# Altium schematic sheet Modul.SchDoc
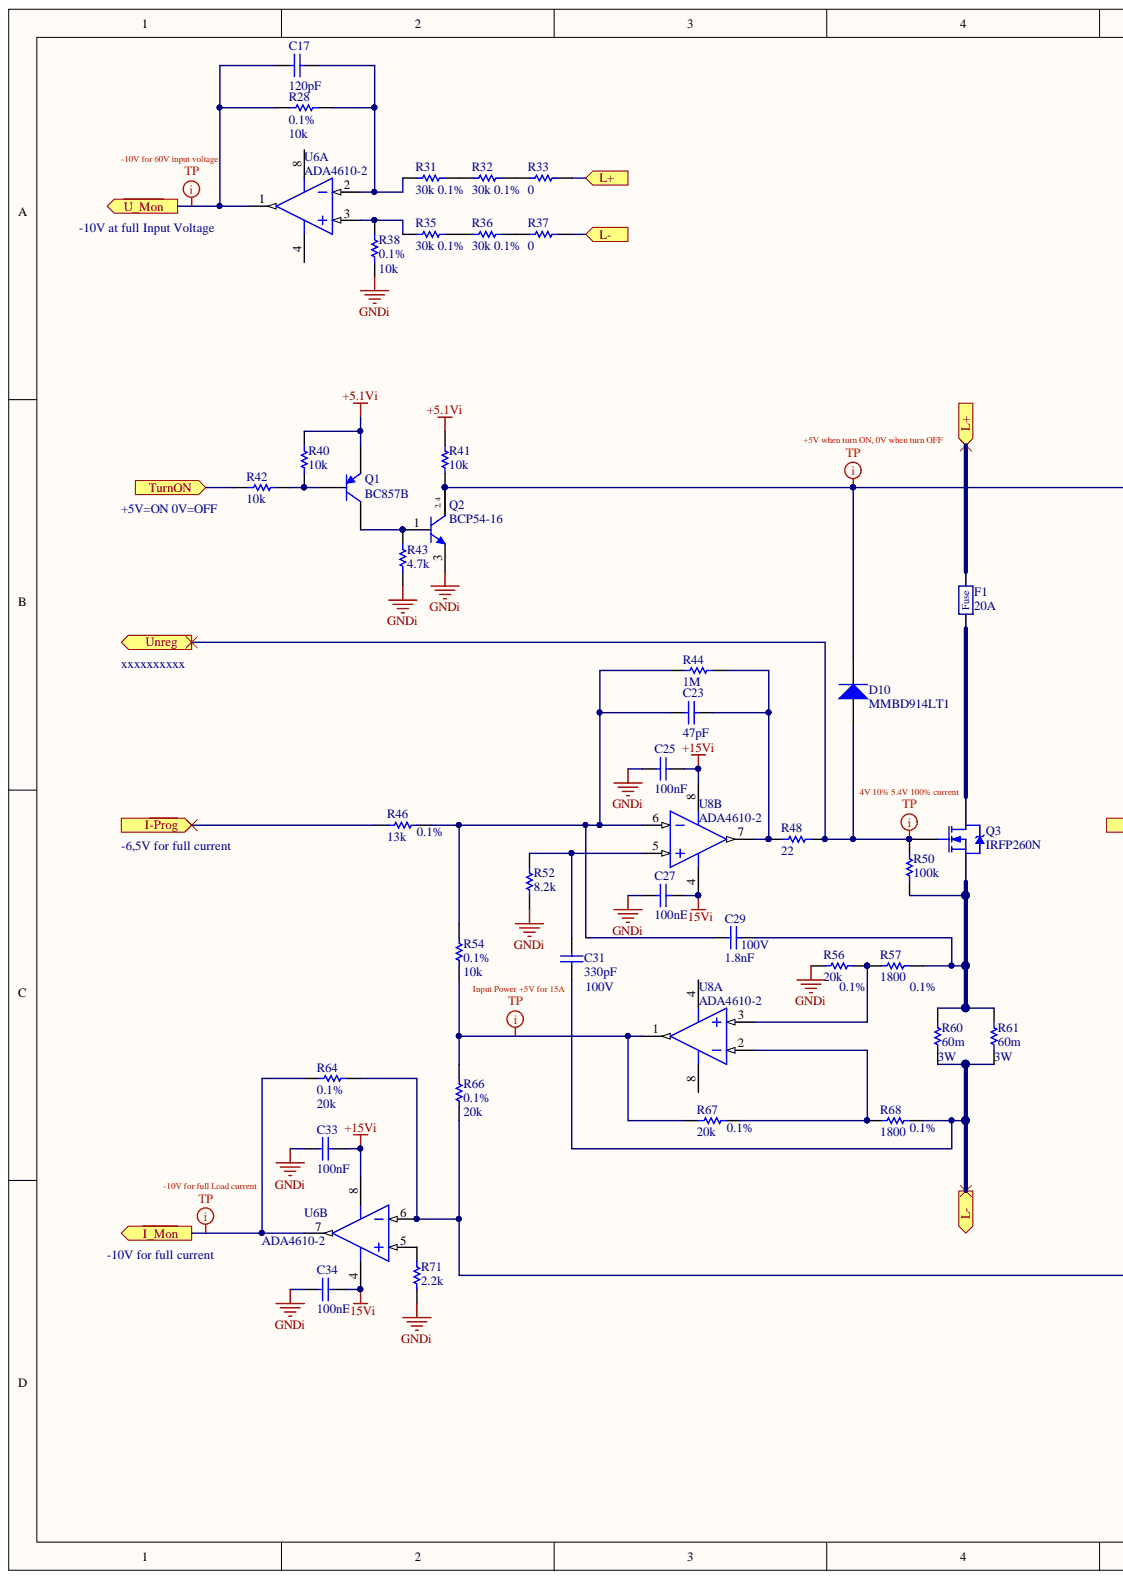
[diagram p0: Modul.SchDoc, left]
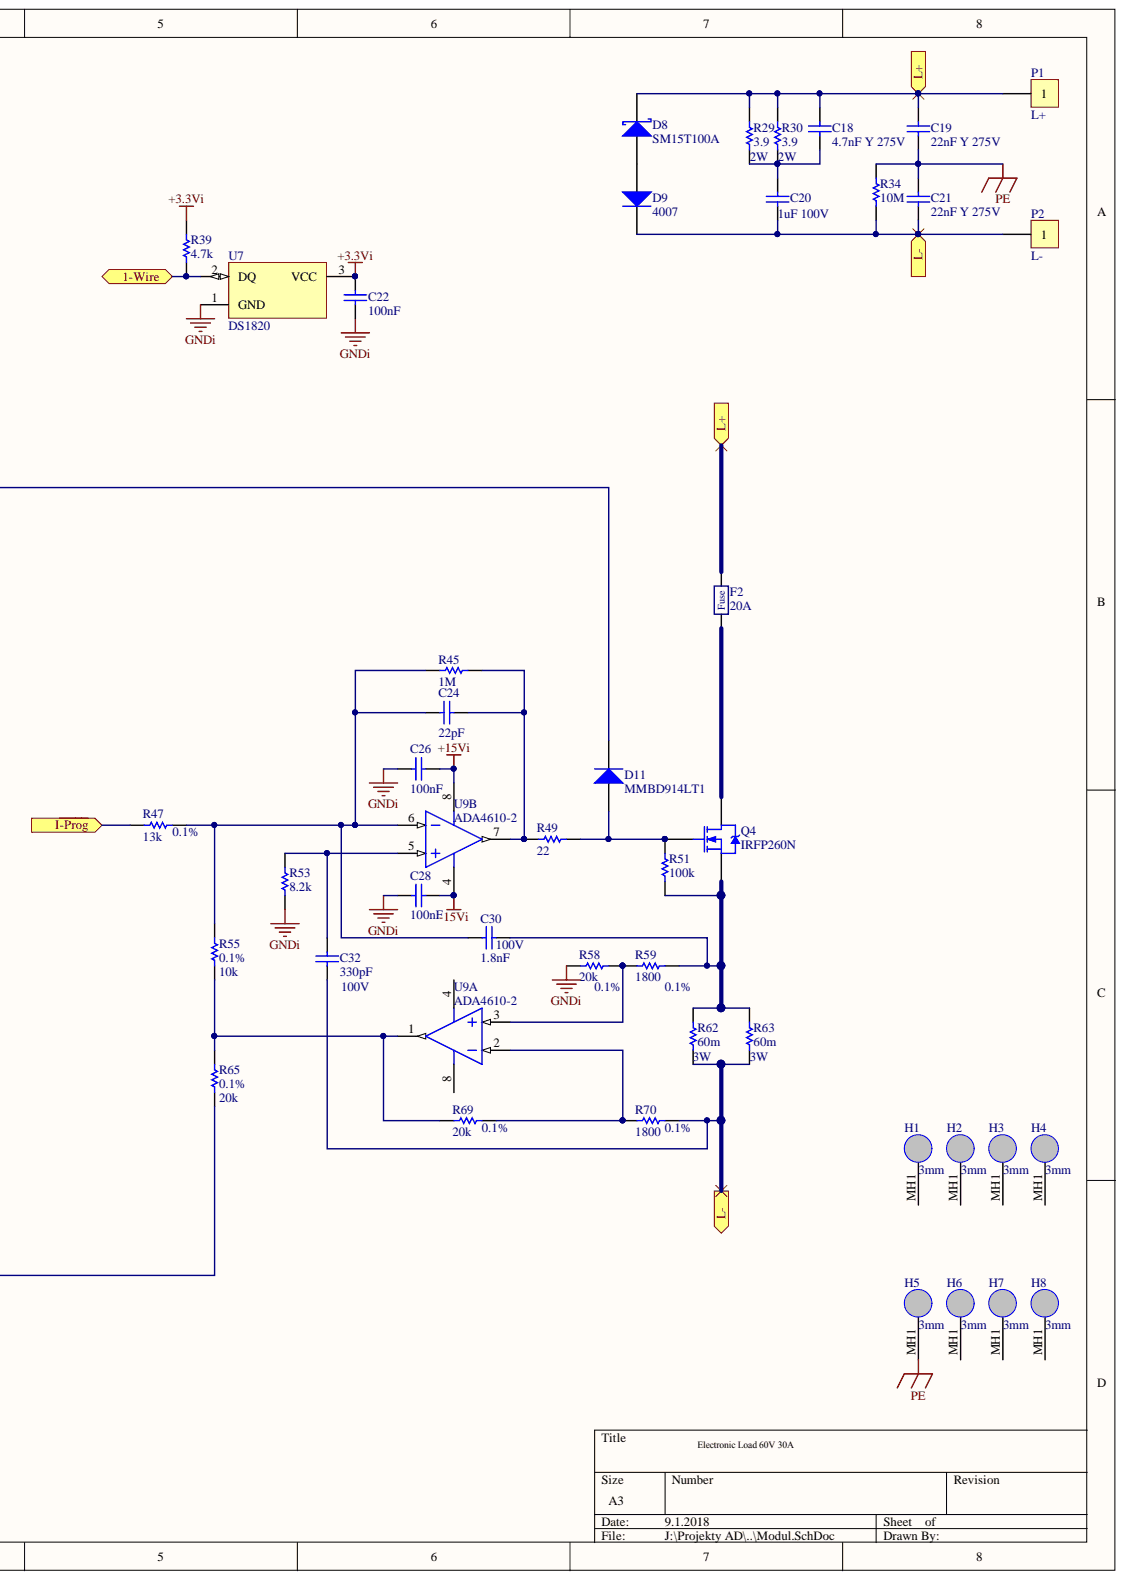
[diagram p1: Modul.SchDoc, right]

## Parts
C17  value 120pF  [2012[0805]-C]
C18  4.7nF Y 275V  value 4.7nF  [Capacitor 5mm B]
C19  22nF Y 275V  value 22nF  [Capacitor 5mm B]
C20  1uF 100V  [2225 - C]
C21  22nF Y 275V  value 22nF  [Capacitor 5mm B]
C22  value 100nF  [1608[0603] - C]  (hidden comment: Cap)
C23  value 47pF  [2012[0805]-C]
C24  value 22pF  [2012[0805]-C]
C25  value 100nF  [1608[0603] - C]  (hidden comment: Cap)
C26  value 100nF  [1608[0603] - C]  (hidden comment: Cap)
C27  value 100nF  [1608[0603] - C]  (hidden comment: Cap)
C28  value 100nF  [1608[0603] - C]  (hidden comment: Cap)
C29  100V  value 1.8nF  [2012[0805]-C]
C30  100V  value 1.8nF  [2012[0805]-C]
C31  100V  value 330pF  [2012[0805]-C]
C32  100V  value 330pF  [2012[0805]-C]
C33  value 100nF  [1608[0603] - C]  (hidden comment: Cap)
C34  value 100nF  [1608[0603] - C]  (hidden comment: Cap)
D8  SM15T100A  [SMC - dioda]
D9  4007  [MELF-D5022-2009]
D10  MMBD914LT1  [SOT23-1]
D11  MMBD914LT1  [SOT23-1]
F1  20A  [Fuse 16A]
F2  20A  [Fuse 16A]
H1  3mm  [Mount Hole]
H2  3mm  [Mount Hole]
H3  3mm  [Mount Hole]
H4  3mm  [Mount Hole]
H5  3mm  [Mount Hole]
H6  3mm  [Mount Hole]
H7  3mm  [Mount Hole]
H8  3mm  [Mount Hole]
P1  L+  [Terminal 4mm]
P2  L-  [Terminal 4mm - B]
Q1  BC857B  [SOT23]
Q2  BCP54-16  [SOT223_L]
Q3  IRFP260N  [TO3PN]
Q4  IRFP260N  [TO3PN]
R28  0.1%  value 10k  [2012[0805]-R]
R29  2W  value 3.9  [6432(2512)]
R30  2W  value 3.9  [6432(2512)]
R31  0.1%  value 30k  [3216[1206] - R]
R32  0.1%  value 30k  [3216[1206] - R]
R33  0.1%  value 0  [3216[1206] - R]
R34  1%  value 10M  [2012[0805]-R]
R35  0.1%  value 30k  [3216[1206] - R]
R36  0.1%  value 30k  [3216[1206] - R]
R37  0.1%  value 0  [3216[1206] - R]
R38  0.1%  value 10k  [2012[0805]-R]
R39  1%  value 4.7k  [2012[0805]-R]
R40  1%  value 10k  [2012[0805]-R]
R41  1%  value 10k  [2012[0805]-R]
R42  1%  value 10k  [2012[0805]-R]
R43  value 4.7k  [2012[0805]-R]  (hidden comment: 1%)
R44  1%  value 1M  [2012[0805]-R]
R45  1%  value 1M  [2012[0805]-R]
R46  0.1%  value 13k  [2012[0805]-R]
R47  0.1%  value 13k  [2012[0805]-R]
R48  value 22  [2012[0805]-R]  (hidden comment: 1%)
R49  value 22  [2012[0805]-R]  (hidden comment: 1%)
R50  1%  value 100k  [2012[0805]-R]
R51  1%  value 100k  [2012[0805]-R]
R52  value 8.2k  [2012[0805]-R]  (hidden comment: 1%)
R53  value 8.2k  [2012[0805]-R]  (hidden comment: 1%)
R54  0.1%  value 10k  [2012[0805]-R]
R55  0.1%  value 10k  [2012[0805]-R]
R56  0.1%  value 20k  [2012[0805]-R]
R57  0.1%  value 1800  [2012[0805]-R]
R58  0.1%  value 20k  [2012[0805]-R]
R59  0.1%  value 1800  [2012[0805]-R]
R60  3W  value 60m  [Resistor Managin Low Ind]
R61  3W  value 60m  [Resistor Managin Low Ind]
R62  3W  value 60m  [Resistor Managin Low Ind]
R63  3W  value 60m  [Resistor Managin Low Ind]
R64  0.1%  value 20k  [2012[0805]-R]
R65  0.1%  value 20k  [2012[0805]-R]
R66  0.1%  value 20k  [2012[0805]-R]
R67  0.1%  value 20k  [2012[0805]-R]
R68  0.1%  value 1800  [2012[0805]-R]
R69  0.1%  value 20k  [2012[0805]-R]
R70  0.1%  value 1800  [2012[0805]-R]
R71  value 2.2k  [2012[0805]-R]  (hidden comment: 1%)
U6  ADA4610-2  [SOIC8_L]  (parts A,B)
U7  DS1820  [TO-92A]
U8  ADA4610-2  [SOIC8_L]  (parts A,B)
U9  ADA4610-2  [SOIC8_L]  (parts A,B)

## Ports
1-Wire, I~{-Prog}, L+, L-, TurnON, Unreg, ~{I_Mon}, ~{U_Mon}

## Nets
+3.3Vi: C22.1 R39.2 U7.3
+5.1Vi: Q1.1 R40.1 R41.1
+15Vi: C25.1 C26.1 C33.1 U6.8 U8.8 U9.8
-15Vi: C27.1 C28.1 C34.1 U6.4 U8.4 U9.4
GNDi: C22.2 C25.2 C26.2 C27.2 C28.2 C33.2 C34.2 Q2.3 R38.1 R43.1 R52.2 R53.2 R56.2 R58.2 R71.2 U7.1
PE: C19.1 C21.2 H5.MH1 R34.2
1-Wire: R39.1 U7.2 ; port 1-Wire
I~{-Prog}: R46.2 R47.2 ; port I~{-Prog}
~{I_Mon}: R64.1 U6.7 ; port ~{I_Mon}
~{U_Mon}: C17.1 R28.1 U6.1 ; port ~{U_Mon}
TurnON: R42.1 ; port TurnON
Unreg: D10.1 Q3.1 R48.1 R50.1 ; port Unreg
NetC17_2: C17.2 R28.2 R31.2 U6.2
NetC18_1: C18.1 C20.2 R29.1 R30.1
NetC18_2: C18.2 C19.2 D8.2 F1.2 F2.2 P1.1 R29.2 R30.2 R33.1
NetC20_1: C20.1 C21.1 C31.1 C32.1 D9.2 P2.1 R34.1 R37.1 R60.2 R61.2 R62.2 R63.2 R68.1 R70.1
NetC23_1: C23.1 C29.2 R44.2 R46.1 R54.1 U8.6
NetC23_2: C23.2 R44.1 R48.2 U8.7
NetC24_1: C24.1 C30.2 R45.2 R47.1 R55.1 U9.6
NetC24_2: C24.2 R45.1 R49.2 U9.7
NetC29_1: C29.1 Q3.3 R50.2 R57.1 R60.1 R61.1
NetC30_1: C30.1 Q4.3 R51.2 R59.1 R62.1 R63.1
NetC31_2: C31.2 R52.1 U8.5
NetC32_2: C32.2 R53.1 U9.5
NetD8_1: D8.1 D9.1
NetD10_3: D10.3 D11.3 Q2.2 Q2.4 R41.2
NetD11_1: D11.1 Q4.1 R49.1 R51.1
NetF1_1: F1.1 Q3.2
NetF2_1: F2.1 Q4.2
NetQ1_2: Q1.2 R40.2 R42.2
NetQ1_3: Q1.3 Q2.1 R43.2
NetR31_1: R31.1 R32.2
NetR32_1: R32.1 R33.2
NetR35_1: R35.1 R36.2
NetR35_2: R35.2 R38.2 U6.3
NetR36_1: R36.1 R37.2
NetR54_2: R54.2 R66.1 R67.2 U8.1
NetR55_2: R55.2 R65.1 R69.2 U9.1
NetR56_1: R56.1 R57.2 U8.3
NetR58_1: R58.1 R59.2 U9.3
NetR64_2: R64.2 R65.2 R66.2 U6.6
NetR67_1: R67.1 R68.2 U8.2
NetR69_1: R69.1 R70.2 U9.2
NetR71_1: R71.1 U6.5

Not connected: H1 MH1; H2 MH1; H3 MH1; H4 MH1; H6 MH1; H7 MH1; H8 MH1

## Library data of the parts
C17 C22-C34 = Cap: Capacitor; Component Kind: Standard; LatestRevisionDate: 17-Jul-2002; LatestRevisionNote: Re-released for DXP Platform.; Library Name: Miscellaneous Devices.IntLib; PackageReference: RAD-0.3; Published: 8-Jun-2000; Signal Integrity: Cap; Simulation: CAP; Publisher: Altium Limited
C18 C19 C21 = Cap X2 15: Capacitor 4.7nF Y2 275V; Component Kind: Standard; LatestRevisionDate: 17-Jul-2002; LatestRevisionNote: Re-released for DXP Platform.; PackageReference: C1206; Published: 8-Jun-2000; Signal Integrity: Cap Semi; Simulation: CAP; Supplier 1: TME; Supplier Part Number 1: CC4K-4N7; Library Name: ADUClib.SCHLIB; Publisher: Altium Limited
C20 = Cap X2 15: Capacitor 4.7nF Y2 275V; Value: 2.2uF; Component Kind: Standard; LatestRevisionDate: 17-Jul-2002; LatestRevisionNote: Re-released for DXP Platform.; PackageReference: C1206; Published: 8-Jun-2000; Signal Integrity: Cap Semi; Simulation: CAP; Supplier 1: TME; Supplier Part Number 1: CC4K-4N7; Library Name: ADUClib.SCHLIB; Publisher: Altium Limited
D8 = Transil: P6SMB15CA SMD; Component Kind: Standard; Library Name: ADUClib.SCHLIB
D9 = Diode: Default Diode; Code_JEDEC: DO-214-AB; Component Kind: Standard; LatestRevisionDate: 17-Jul-2002; LatestRevisionNote: Re-released for DXP Platform.; PackageDocument: Sep-1996; PackageReference: SMC; Published: 8-Jun-2000; Signal Integrity: Diode; Simulation: DIODE; Supplier 1: TME; Supplier Part Number 1: SUF4007-DIO; Library Name: ADUClib.SCHLIB; Publisher: Altium Limited
D10 D11 = MMBD914LT1: High-Speed Switching Diode; Note: Pin 2 is not connected and not drawn.; Component Kind: Standard; ComponentLink1Description: Manufacturer Link; ComponentLink1URL: http://www.onsemi.com/site/products/landing?taxonomyID=194; ComponentLink2Description: Datasheet; ComponentLink2URL: http://www.onsemi.com/pub/Collateral/MMBD914LT1-D.PDF; DatasheetVersion: 1997; LatestRevisionDate: 17-Jul-2002; LatestRevisionNote: Re-released for DXP Platform.; PackageDescription: SOT-23; 3 Leads; Body 3.0 x 2.6 mm, inc. leads (LxW max); PackageReference: 318-08; PackageVersion: 1997; Published: 29-Sep-2000; Signal Integrity: MMBD914LT1; Library Name: ADUClib.SCHLIB; Publisher: Altium Limited
F1 F2 = Fuse 16A: Fuse 5x20; Component Kind: Standard; ComponentLink1Description: http://www.sibafuses.com; ComponentLink1URL: http://www.sibafuses.com/pdf/g.pdf; PackageDescription: RM5,08 8,35mm x 7.7mm; TME: http://www.tme.eu/cz/katalog/?art=GTO-5U/950#id_category%3D100085%26cleanParameters%3D1; Library Name: ADUClib.SCHLIB
H1-H8 = Hole: Component Kind: Standard; Price: 0; Library Name: ADUClib.SCHLIB
P1 P2 = Header 1: Header, 1-Pin; Component Kind: Standard; LatestRevisionDate: 17-Jul-2002; LatestRevisionNote: Re-released for DXP Platform.; Signal Integrity: Connector; Library Name: ADUClib.SCHLIB; Publisher: Altium Limited
Q1 = BC857B: Amplifier Transistor PNP Silicon; Component Kind: Standard; ComponentLink1Description: Manufacturer Link; ComponentLink1URL: http://www.google.cz/url?sa=t&rct=j&q=bc857b&source=web&cd=1&cad=rja&ved=0CDMQFjAA&url=http%3A%2F%2Fwww.datasheetcatalog.org%2Fdatasheet%2FSGSThomsonMicroelectronics%2FmXytvuw.pdf&ei=2lGSUZPqIYnotQaijICgDQ&usg=AFQjCNEm0i04ptMEFToriaPPV8wgqTKVOA&bvm=bv.46471029,d.Yms; ComponentLink2Description: Datasheet; ComponentLink2URL: http://www.google.cz/url?sa=t&rct=j&q=bc857b&source=web&cd=3&cad=rja&ved=0CEIQFjAC&url=http%3A%2F%2Fwww.nxp.com%2Fdocuments%2Fdata_sheet%2FBC856_BC857_BC858.pdf&ei=2lGSUZPqIYnotQaijICgDQ&usg=AFQjCNGdj3tbdI0CG45Z4CM3kgP5DAmnUA&bvm=bv.46471029,d.Yms; DatasheetVersion: 1996; LatestRevisionDate: 17-Jul-2002; LatestRevisionNote: Re-released for DXP Platform.; PackageDescription: TO, Flat Index; 3 In-Line, Axial Leads; Body Dia. 4.8mm; Leads 0.48 x 0.5 mm (max); PackageReference: 29-04; PackageVersion: 1996; Published: 29-Sep-2000; Library Name: ADUClib.SCHLIB; Publisher: Altium Limited
Q2 = BCP54-16: NPN Silicon AF Transistor, 45 V VCEO, 1000 mA IC, SOT223, Reel, Green; Manufacturer: Infineon; fT (typ): 100 MHz; Green: TRUE; IC: 1,000 mA; ICBO (max): 100 nA; ICM (max): 1,500 mA; Ptot (max): 2,000 mW; VCBO (max): 45 V; VCE: 2 V; VEBO (max): 5 V; Component Kind: Standard; ComponentLink1Description: Manufacturer URL; ComponentLink1URL: http://www.infineon.com/; ComponentLink2Description: Datasheet; ComponentLink2URL: http://www.infineon.com/dgdl/bcp54_bcp55_bcp56.pdf?folderId=db3a304314dca38901155ffc06d51dc7&fileId=db3a3043156fd5730115895261c902e2; ComponentLink3Description: Package Specification; ComponentLink3URL: http://www.infineon.com/dgdl/SOT223-Package_Overview.pdf?folderId=db3a30431936bc4b0119530470b03c3d&fileId=db3a30431936bc4b0119531d40b93c5c; IC (max): 1,000 mA; Library Name: Infineon Transistor & Diode.IntLib; Mounting Technology: Surface Mount; PackageDescription: 4-Pin Small Outline Transistor Package, 6.5 x 3.5 mm Body, 2.3 mm Pitch; PackageReference: SOT223 (SC-73); PackageVersion: Apr-08; Packing: Reel; PartNumber: BCP54-16; Polarity: NPN; VCEO (max): 45 V
Q3 Q4 = IRL2910: HEXFET Power MOSFET; Note: Pin 2 is electrically connected to pin 4 (Drain).; Component Kind: Standard; ComponentLink1Description: Manufacturer Link; ComponentLink1URL: http://www.irf.com/; ComponentLink2Description: Datasheet; ComponentLink2URL: http://www.irf.com/product-info/datasheets/data/irl2910.pdf; DatasheetVersion: May-1998; LatestRevisionDate: 17-Jul-2002; LatestRevisionNote: Re-released for DXP Platform.; Library Name: IR Discrete MOSFET - Power.IntLib; PackageDescription: TO, Thru-Hole, Vertical, Heatsink Mounted; 3 In-Line Leads; Pitch 2.54 mm; PackageReference: TO-220AB; PackageVersion: Feb-1997; Price: 81,3; Published: 8-Jun-2000; Supplier 1: TME; Supplier Part Number 1: FDA50N50; Publisher: Altium Limited
R28 = ERJ6GE: Thick Film Chip Resistor, 1 Ohm to 10M Ohm Range, 5% Tolerance, 0805 Size, 0.125 W; Note: If flow soldering, adjust pad width of PCB Footprint to 0.7 - 0.8 times the width of the resistor.; Value: 10k; Code_IPC: 2012 [0805]; Component Kind: Standard; ComponentLink1Description: Manufacturer Link; ComponentLink1URL: http://www.panasonic.com/industrial/components/resistive_products.htm; ComponentLink2Description: Datasheet; ComponentLink2URL: http://www.panasonic.com/industrial/components/pdf/AOA0000CE1.pdf; LatestRevisionDate: 19-Mar-2004; LatestRevisionNote: Silkscreen size reduced.; Library Name: Panasonic Resistor.IntLib; PackageDocument: Mar-2003; PackageReference: 6-0805; Published: 17-Dec-2003; Signal Integrity: Res; Simulation: RESISTOR; Publisher: Altium Limited
R29-R71 = ERJ6GE: Thick Film Chip Resistor, 1 Ohm to 10M Ohm Range, 5% Tolerance, 0805 Size, 0.125 W; Note: If flow soldering, adjust pad width of PCB Footprint to 0.7 - 0.8 times the width of the resistor.; Code_IPC: 2012 [0805]; Component Kind: Standard; ComponentLink1Description: Manufacturer Link; ComponentLink1URL: http://www.panasonic.com/industrial/components/resistive_products.htm; ComponentLink2Description: Datasheet; ComponentLink2URL: http://www.panasonic.com/industrial/components/pdf/AOA0000CE1.pdf; LatestRevisionDate: 19-Mar-2004; LatestRevisionNote: Silkscreen size reduced.; Library Name: Panasonic Resistor.IntLib; PackageDocument: Mar-2003; PackageReference: 6-0805; Published: 17-Dec-2003; Signal Integrity: Res; Simulation: RESISTOR; Publisher: Altium Limited
  R40 R41 R43-R47 R52-R56 R58 R64-R67 R69: Supplier 1: Farnell; Supplier Part Number 1: 1653304
  R57 R59 R68 R70: Supplier 1: TME; Supplier Part Number 1: SMD0805-1K-HP25
U6 U8 U9 = ADA4610-2: Low Noise, Precision, Rail-to-Rail Output,  JFET Dual Operational Amplifier; Code_IPC: LFCSP_VQ CP16; Code_JEDEC: MO-220-VGGC; Component Kind: Standard; ComponentLink1Description: Manufacturer Link; ComponentLink1URL: http://www.analog.com/static/imported-files/data_sheets/ADA4610-2.pdf; DatasheetVersion: 2010; LatestRevisionDate: 13-Jun-2010; LatestRevisionNote: IPC-7351 Footprint Added.; PackageDescription: 16-Lead Lead Frame Chip Scale Package [LFCSP_VQ]; PackageReference: 751-02; Published: 17-Jul-2002; Library Name: ADUClib.SCHLIB; Publisher: CD Ltd.
U7 = DS1820: 1-Wire Digital Thermometer; ComponentAvailability: Obsolete; Component Kind: Standard; ComponentLink1Description: Manufacturer Link; ComponentLink1URL: http://www.maxim-ic.com/; ComponentLink2Description: Datasheet; ComponentLink2URL: http://pdfserv.maxim-ic.com/en/ds/DS1820-DS1820S.pdf; ComponentLink3Description: Discontinuation Notice; ComponentLink3URL: http://www.maxim-ic.com/qa/pdfs/non-obsolescence-letter.pdf; DatasheetVersion: 5-Mar-1998; LatestRevisionDate: 17-Jul-2002; LatestRevisionNote: Re-released for DXP Platform.; Library Name: Dallas Sensor Temperature Sensor.IntLib; PackageDescription: TO, Flat Index; 3 In-Line, Axial Leads; Body Dia. 4.6mm; Leads 0.56 x 0.51 mm (max); PackageReference: PR35; PackageVersion: 1999; Published: 13-Oct-2000; Publisher: Altium Limited
